# Altium schematic sheet FeedbackLoop.SchDoc
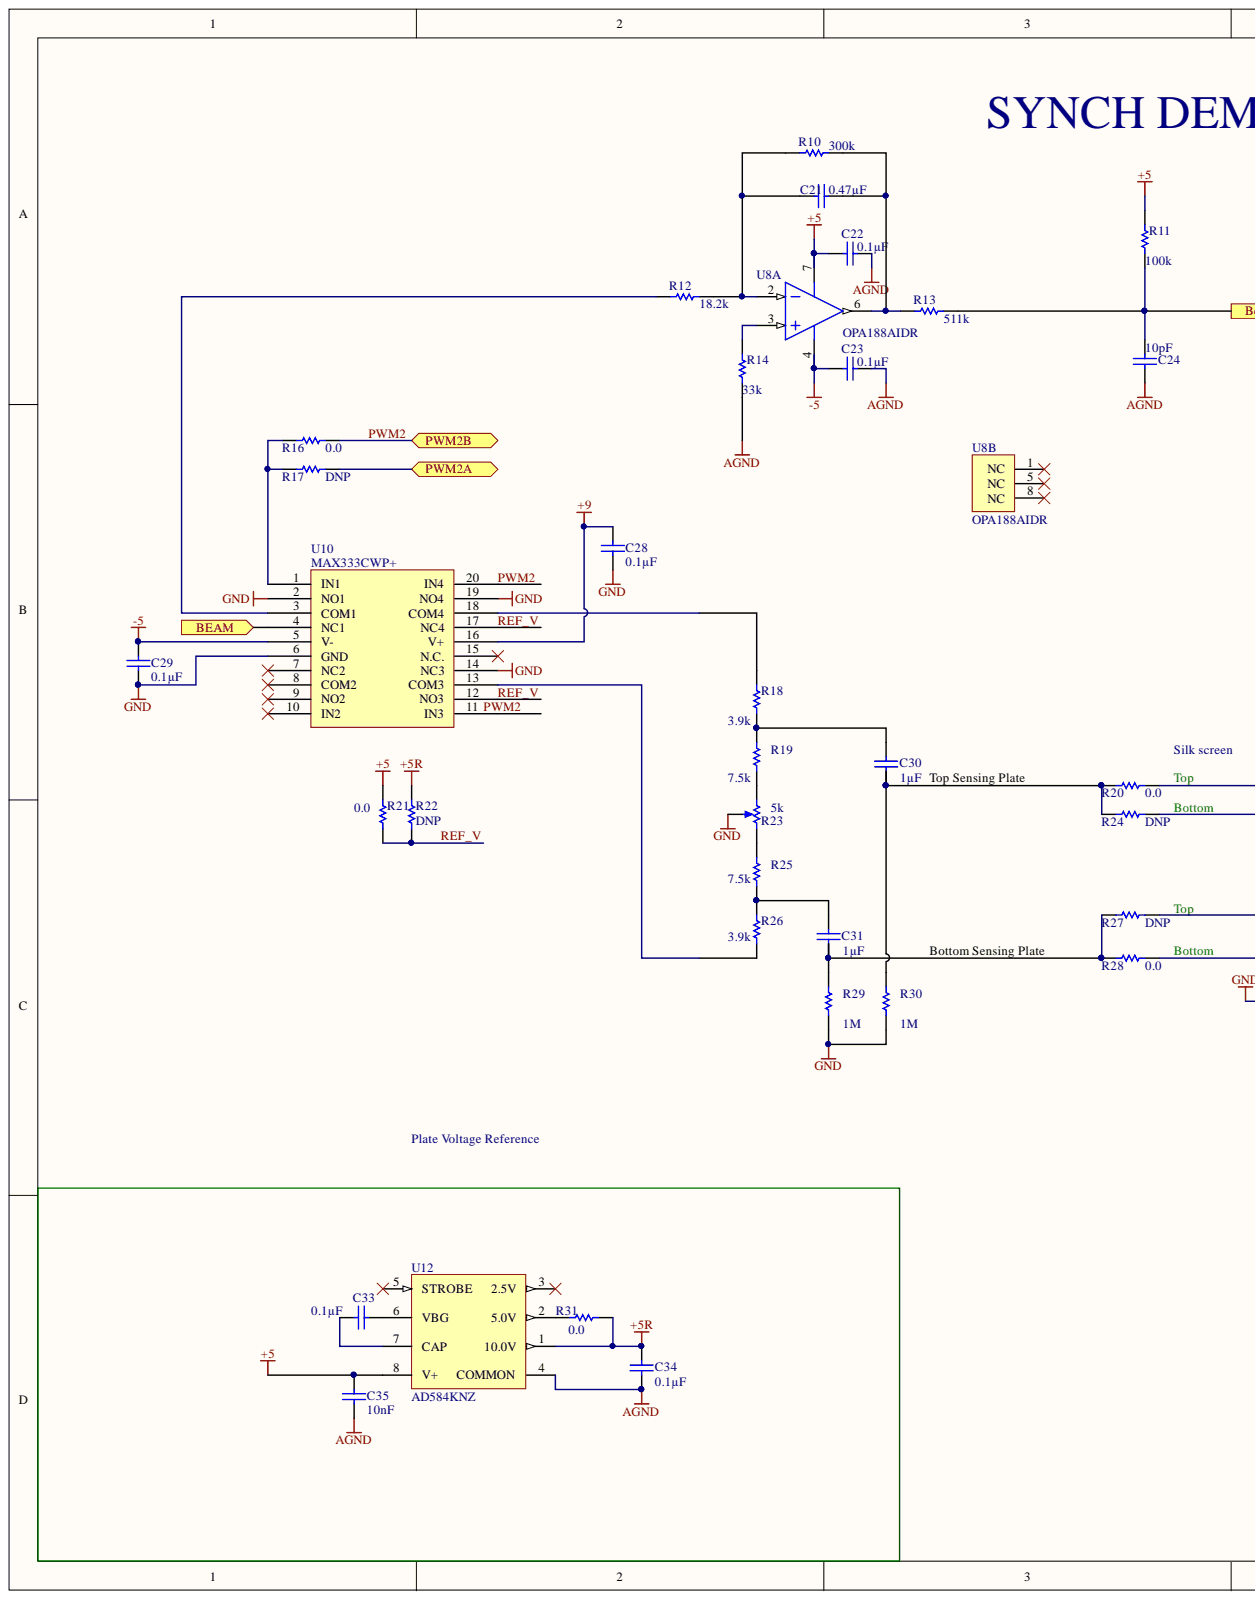
[diagram p0: FeedbackLoop.SchDoc, left]
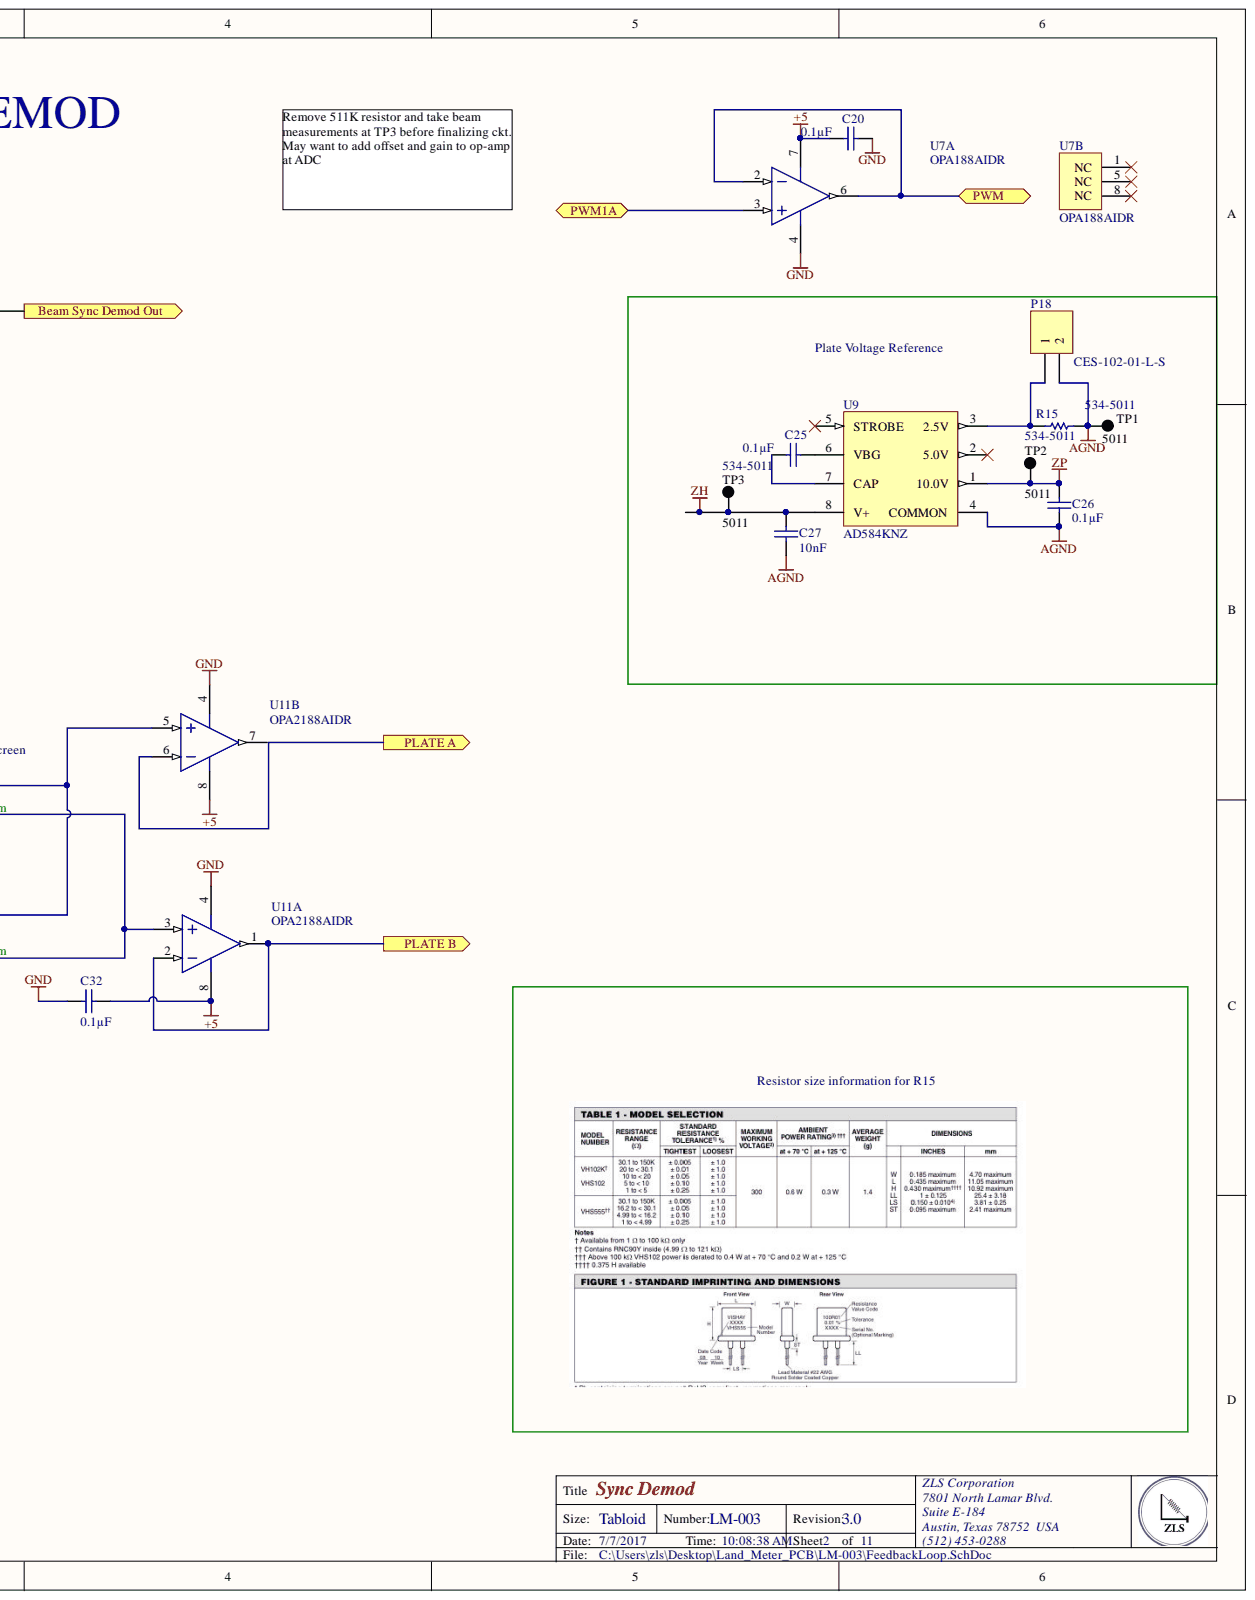
[diagram p1: FeedbackLoop.SchDoc, right]

## Parts
C20  Capacitance: 0.1µF  [CAPC0805(2012)100_N]  (hidden comment: CAP 100nF 25V 0805(2012))
C21  Capacitance: 0.47µF  [CAPC0805(2012)145_N]  (hidden comment: CAP CER 0.47UF 25V X7R 0805)
C22  Capacitance: 0.1µF  [CAPC0805(2012)145_N]  (hidden comment: CAP CER 0.1UF 16V X7R 0805)
C23  Capacitance: 0.1µF  [CAPC0805(2012)145_N]  (hidden comment: CAP CER 0.1UF 25V X7R 0805)
C24  Capacitance: 10pF  [CAPC0805(2012)145_N]  (hidden comment: CAP CER 10PF 200V C0G/NP0 0805)
C25  Capacitance: 0.1µF  [CAPC0805(2012)100_N]  (hidden comment: CAP 100nF 25V 0805(2012))
C26  Capacitance: 0.1µF  [CAPC0805(2012)145_N]  (hidden comment: CAP CER 0.1UF 25V X7R 0805)
C27  Capacitance: 10nF  [CAPC0805(2012)145_N]  (hidden comment: Multilayer Ceramic Capacitors MLCC - SMD/SMT 0.01uF 50volts 10% X7R)
C28  Capacitance: 0.1µF  [CAPC0805(2012)145_N]  (hidden comment: CAP CER 0.1UF 25V X7R 0805)
C29  Capacitance: 0.1µF  [CAPC0805(2012)145_N]  (hidden comment: CAP CER 0.1UF 25V X7R 0805)
C30  Capacitance: 1µF  [CAPC0805(2012)155_L]  (hidden comment: CAP CER 1UF 25V X7R 0805)
C31  Capacitance: 1µF  [CAPC0805(2012)155_L]  (hidden comment: CAP CER 1UF 25V X7R 0805)
C32  Capacitance: 0.1µF  [CAPC0805(2012)155_L]  (hidden comment: CAP CER 0.1UF 25V X7R 0805)
C33  Capacitance: 0.1µF  [CAPC0805(2012)100_N]  (hidden comment: CAP 100nF 25V 0805(2012))
C34  Capacitance: 0.1µF  [CAPC0805(2012)145_N]  (hidden comment: CAP CER 0.1UF 25V X7R 0805)
C35  Capacitance: 10nF  [CAPC0805(2012)145_N]  (hidden comment: Multilayer Ceramic Capacitors MLCC - SMD/SMT 0.01uF 50volts 10% X7R)
P18  LOW PROFILE .025" SQUARE STRIPS  [CES-102-01-L-S]  (value not drawn)
R10  Resistance (Ohms): 300k  [RESC0805(2012)_M]  (hidden comment: RES SMD 300K OHM 1% 1/8W 0805)
R11  Resistance (Ohms): 100k  [RESC0805(2012)_M]  (hidden comment: RES SMD 100K OHM 1% 1/2W 0805)
R12  Resistance (Ohms): 18.2k  [RESC0805(2012)_M]  (hidden comment: RES SMD 18.2K OHM 1% 1/8W 0805)
R13  Resistance (Ohms): 511k  [RESC0805(2012)_M]  (hidden comment: RES SMD 511K OHM 1% 1/8W 0805)
R14  Resistance (Ohms): 33k  [RESC0805(2012)_M]  (hidden comment: RES SMD 33K OHM 1% 1/2W 0805)
R15  TBD  [VH102K]  (value not drawn)
R16  Resistance (Ohms): 0.0  [RESC0805(2012)_M]  (hidden comment: RES SMD 0.0 OHM JUMPER 1/8W 0805)
R17  Resistance (Ohms): DNP  [RESC0805(2012)_M]  (hidden comment: RES SMD 0.0 OHM JUMPER 1/8W 0805)
R18  Resistance (Ohms): 3.9k  [RESC0805(2012)_N]  (hidden comment: RES SMD 3.9K OHM 5% 1/8W 0805)
R19  Resistance (Ohms): 7.5k  [RESC0805(2012)_N]  (hidden comment: RES SMD 7.5K OHM 1% 1/8W 0805)
R20  Resistance (Ohms): 0.0  [RESC0805(2012)_M]  (hidden comment: RES SMD 0.0 OHM JUMPER 1/8W 0805)
R21  Resistance (Ohms): 0.0  [RESC0805(2012)_M]  (hidden comment: RES SMD 0.0 OHM JUMPER 1/8W 0805)
R22  DNP  [RESC0805(2012)_M]
R23  Resistance (Ohms): 5k  [Trimmer 2.54]  (hidden comment: TRIMMER 5K OHM 0.25W PC PIN)
R24  Resistance (Ohms): DNP  [RESC0805(2012)_M]  (hidden comment: RES SMD 0.0 OHM JUMPER 1/8W 0805)
R25  Resistance (Ohms): 7.5k  [RESC0805(2012)_N]  (hidden comment: RES SMD 7.5K OHM 1% 1/8W 0805)
R26  Resistance (Ohms): 3.9k  [RESC0805(2012)_N]  (hidden comment: RES SMD 3.9K OHM 5% 1/8W 0805)
R27  Resistance (Ohms): DNP  [RESC0805(2012)_M]  (hidden comment: RES SMD 0.0 OHM JUMPER 1/8W 0805)
R28  Resistance (Ohms): 0.0  [RESC0805(2012)_M]  (hidden comment: RES SMD 0.0 OHM JUMPER 1/8W 0805)
R29  Resistance (Ohms): 1M  [RESC0805(2012)_N]  (hidden comment: RES SMD 1M OHM 1% 1/8W 0805)
R30  Resistance (Ohms): 1M  [RESC0805(2012)_N]  (hidden comment: RES SMD 1M OHM 1% 1/8W 0805)
R31  Resistance (Ohms): 0.0  [RESC0805(2012)_M]  (hidden comment: RES SMD 0.0 OHM JUMPER 1/8W 0805)
TP1  5011  Supplier Part Number 1: 534-5011  [KSTN-5011_V]
TP2  5011  Supplier Part Number 1: 534-5011  [KSTN-5011_V]
TP3  5011  Supplier Part Number 1: 534-5011  [KSTN-5011_V]
U7  OPA188AIDR  [D0008A_M]  (parts A,B)
U8  OPA188AIDR  [D0008A_M]  (parts A,B)
U9  AD584KNZ  [ADI-N-8]
U10  MAX333CWP+  [TSOP65P640X90-20N]
U11  OPA2188AIDR  [D0008A_L]  (parts A,B)
U12  AD584KNZ  [ADI-N-8]

## Ports
BEAM, Beam Sync Demod Out, PLATE A, PLATE B, PWM, PWM1A, PWM2A, PWM2B

## Nets
+5: C20.1 C22.1 C32.1 C35.1 R11.2 R21.2 U7.7 U8.7 U11.8 U12.8(V+)
+5R: C34.1 R22.2 R31.1 U12.1(10.0V)
+9: C28.2 U10.16(V+)
-5: C23.2 C29.1 U8.4 U10.5(V-)
AGND: C22.2 C23.1 C24.2 C26.2 C27.2 C34.2 C35.2 P18.2 R14.1 R15.2 TP1.1 U9.4(COMMON) U12.4(COMMON)
GND: C20.2 C28.1 C29.2 C32.2 R23.2 R29.1 R30.1 U7.4 U10.2(NO1) U10.6(GND) U10.14(NC3) U10.19(NO4) U11.4
ZH: C27.1 TP3.1 U9.8(V+)
ZP: C26.1 TP2.1 U9.1(10.0V)
BEAM: U10.4(NC1) ; port BEAM
Beam Sync Demod Out: C24.1 R11.1 R13.1 ; port Beam Sync Demod Out
PLATE A: U11.6 ; port PLATE A
PLATE B: U11.1 U11.2 ; port PLATE B
PWM: U7.2 U7.6 ; port PWM
PWM1A: U7.3 ; port PWM1A
PWM2: R16.1 U10.20(IN4) ; port PWM2B
PWM2A: R17.1 ; port PWM2A
REF_V: R21.1 R22.1 U10.12(NO3) U10.17(NC4)
NetC21_1: C21.1 R10.2 R12.2 U8.2
NetC21_2: C21.2 R10.1 R13.2 U8.6
NetC25_1: C25.1 U9.7(CAP)
NetC25_2: C25.2 U9.6(VBG)
NetC30_1: C30.1 R20.2 R24.2 R30.2
NetC30_2: C30.2 R18.1 R19.2
NetC31_1: C31.1 R27.2 R28.2 R29.2
NetC31_2: C31.2 R25.1 R26.2
NetC33_1: C33.1 U12.7(CAP)
NetC33_2: C33.2 U12.6(VBG)
NetP18_1: P18.1 R15.1 U9.3(2.5V)
NetR12_1: R12.1 U10.3(COM1)
NetR14_2: R14.2 U8.3
NetR16_2: R16.2 R17.2 U10.1(IN1)
NetR18_2: R18.2 U10.18(COM4)
NetR19_1: R19.1 R23.3
NetR20_1: R20.1 R27.1 U11.5
NetR23_1: R23.1 R25.2
NetR24_1: R24.1 R28.1 U11.3
NetR26_1: R26.1 U10.13(COM3)
NetR31_2: R31.2 U12.2(5.0V)

Not connected: U7 1(NC) 5(NC) 8(NC); U8 1(NC) 5(NC) 8(NC); U9 2(5.0V) 5(STROBE); U10 7(NC2) 8(COM2) 9(NO2) 10(IN2) 11(IN3) 15(N.C.); U11 7; U12 3(2.5V) 5(STROBE)

## Library data of the parts
C20 C25 C33 = CMP-1036-04416-1: CAP 100nF 25V -20% to +80% 0805 (2012 Metric) Thickness 1mm SMD; Manufacturer: Murata Electronics North America; Manufacturer Part Number: GRM21BR71E104KA01L; Temperature Coefficient: X7R; Tolerance: ±10%; Applications: General Purpose; Category: Capacitors; Component Kind: Standard; ComponentLink1Description: http://www.murata.com/~/media/webrenewal/support/library/catalog/products/capacitor/mlcc/c02e.ashx; ComponentLink1URL: http://www.murata.com/~/media/webrenewal/support/library/catalog/products/capacitor/mlcc/c02e.ashx; Features: -; Height - Seated (Max): -; Lead Spacing: -; Lead Style: -; Library Name: Altium Content Vault; Mounting Type: Surface Mount, MLCC; Operating Temperature: -55°C ~ 125°C; Package / Case: 0805 (2012 Metric); Packaging: Cut Tape (CT); Part Status: Active; Pricing 1: 1=0.2, 10=0.145, 100=0.0683, 500=0.04788, 1000=0.03964 (USD); Ratings: -; RoHS: RoHS Compliant; Size / Dimension: 0.079" L x 0.049" W (2.00mm x 1.25mm); Stock 1: 895391; Supplier 1: Digi-Key; Supplier Part Number 1: 490-1673-1-ND; Thickness (Max): 0.053" (1.35mm); Voltage - Rated: 25V
C21 = CMP-1036-04795-1: Manufacturer: Murata Electronics North America; Manufacturer Part Number: GRM219R71E474KA88D; Tolerance: ±10%; Applications: General Purpose; Category: Capacitors; Component Kind: Standard; ComponentLink1Description: http://www.murata.com/~/media/webrenewal/support/library/catalog/products/capacitor/mlcc/c02e.ashx; ComponentLink1URL: http://www.murata.com/~/media/webrenewal/support/library/catalog/products/capacitor/mlcc/c02e.ashx; Description: CAP CER 0.47UF 25V X7R 0805; Features: -; Height - Seated (Max): -; Lead Spacing: -; Lead Style: -; Library Name: Altium Content Vault; Mounting Type: Surface Mount, MLCC; Operating Temperature: -55°C ~ 125°C; Package / Case: 0805 (2012 Metric); Packaging: Digi-Reel®; Part Status: Active; Pricing 1: 1=0.33, 10=0.229, 100=0.1377, 500=0.10324, 1000=0.08718 (USD); Ratings: -; RoHS: RoHS Compliant; Size / Dimension: 0.079" L x 0.049" W (2.00mm x 1.25mm); Stock 1: 575; Supplier 1: Digi-Key; Supplier Part Number 1: 490-11584-6-ND; Temperature Coefficient: X7R; Thickness (Max): 0.037" (0.95mm); Voltage - Rated: 25V
C22 = CMP-1036-04795-1: Manufacturer: Murata Electronics North America; Manufacturer Part Number: GRM219R71C104KA01D; Tolerance: ±10%; Voltage - Rated: 25V; Applications: General Purpose; Category: Capacitors; Component Kind: Standard; ComponentLink1Description: http://www.murata.com/~/media/webrenewal/support/library/catalog/products/capacitor/mlcc/c02e.ashx; ComponentLink1URL: http://www.murata.com/~/media/webrenewal/support/library/catalog/products/capacitor/mlcc/c02e.ashx; Description: CAP CER 0.1UF 16V X7R 0805; Features: -; Height - Seated (Max): -; Lead Spacing: -; Lead Style: -; Library Name: Altium Content Vault; Mounting Type: Surface Mount, MLCC; Operating Temperature: -55°C ~ 125°C; Package / Case: 0805 (2012 Metric); Packaging: Digi-Reel®; Part Status: Active; Pricing 2: 1=0.14, 10=0.098, 100=0.0462, 500=0.0324, 1000=0.02683 (USD); Ratings: -; RoHS: RoHS Compliant; Size / Dimension: 0.079" L x 0.049" W (2.00mm x 1.25mm); Stock 2: 245331; Supplier 2: Digi-Key; Supplier Part Number 1: 490-1673-6-ND; Supplier Part Number 2: 490-1683-6-ND; Temperature Coefficient: X7R; Thickness (Max): 0.053" (1.35mm)
C23 C26 C28 C29 C34 = CMP-1036-04795-1: Manufacturer: Murata Electronics North America; Manufacturer Part Number: GRM21BR71E104KA01L; Tolerance: ±10%; Applications: General Purpose; Category: Capacitors; Component Kind: Standard; ComponentLink1Description: http://www.murata.com/~/media/webrenewal/support/library/catalog/products/capacitor/mlcc/c02e.ashx; ComponentLink1URL: http://www.murata.com/~/media/webrenewal/support/library/catalog/products/capacitor/mlcc/c02e.ashx; Description: CAP CER 0.1UF 25V X7R 0805; Features: -; Height - Seated (Max): -; Lead Spacing: -; Lead Style: -; Library Name: Altium Content Vault; Mounting Type: Surface Mount, MLCC; Operating Temperature: -55°C ~ 125°C; Package / Case: 0805 (2012 Metric); Packaging: Digi-Reel®; Part Status: Active; Pricing 1: 1=0.2, 10=0.145, 100=0.0683, 500=0.04788, 1000=0.03964 (USD); Ratings: -; RoHS: RoHS Compliant; Size / Dimension: 0.079" L x 0.049" W (2.00mm x 1.25mm); Stock 1: 891652; Supplier 1: Digi-Key; Supplier Part Number 1: 490-1673-6-ND; Temperature Coefficient: X7R; Thickness (Max): 0.053" (1.35mm); Voltage - Rated: 25V
C24 = CMP-1036-04795-1: Manufacturer: Murata Electronics North America; Manufacturer Part Number: GRM21A5C2D100JW01D; Temperature Coefficient: C0G, NP0; Tolerance: ±5%; Applications: General Purpose; Category: Capacitors; Component Kind: Standard; ComponentLink1Description: http://search.murata.co.jp/Ceramy/image/img/A01X/G101/ENG/GRM21A5C2D100JW01-01.pdf; ComponentLink1URL: http://search.murata.co.jp/Ceramy/image/img/A01X/G101/ENG/GRM21A5C2D100JW01-01.pdf; Description: CAP CER 10PF 200V C0G/NP0 0805; Failure Rate: -; Features: -; Height - Seated (Max): -; Lead Spacing: -; Lead Style: -; Library Name: Altium Content Vault; Mounting Type: Surface Mount, MLCC; Operating Temperature: -55°C ~ 125°C; Package / Case: 0805 (2012 Metric); Packaging: Cut Tape (CT); Part Status: Active; Pricing 1: 1=0.3, 10=0.205, 100=0.1038, 500=0.07104, 1000=0.06285 (USD); Ratings: -; RoHS: RoHS Compliant; Size / Dimension: 0.079" L x 0.049" W (2.00mm x 1.25mm); Stock 1: 957; Supplier 1: Digi-Key; Supplier Part Number 1: 490-14649-1-ND; Thickness (Max): 0.039" (1.00mm); Voltage - Rated: 200V
C27 C35 = CMP-1036-04795-1: Manufacturer: Kemet; Manufacturer Part Number: C0805C103K5RACAUTO; Voltage Rating: 50V; Case/Package: 0805; Case Code (Imperial): 0805; Case Code (Metric): 2012; Component Kind: Standard; ComponentLink1Description: https://datasheet.ciiva.com/22700/1693454-22700519.pdf; ComponentLink1URL: https://datasheet.ciiva.com/22700/1693454-22700519.pdf; ComponentLink2Description: https://datasheet.ciiva.com/7842/1693454-7842175.pdf; ComponentLink2URL: https://datasheet.ciiva.com/7842/1693454-7842175.pdf; Contact Plating: Tin; Depth: 1.25mm; Description: Multilayer Ceramic Capacitors MLCC - SMD/SMT 0.01uF 50volts 10% X7R; Dielectric: X7R; Dielectric Material: Ceramic; Height: 0.78mm; Length: 2mm; Library Name: Altium Content Vault; Max Operating Temperature: 125°C; Min Operating Temperature: -55°C; Mount: Surface Mount; Package Quantity: 1; Packaging: Tape and Reel; Pricing 1: 1=0.12, 10=0.087, 100=0.041, 500=0.0287, 1000=0.0238 (USD); Radiation Hardening: No; REACH SVHC: No SVHC; Stock 1: 63236; Supplier 1: Digi-Key; Supplier Part Number 1: 399-6924-1-ND; Termination: SMD/SMT; Thickness: 0.035inch; Tolerance: 10%; Voltage Rating (DC): 50V; Width: 0.049inch
C30 C31 = CMP-1036-04882-1: Manufacturer: Murata Electronics North America; Manufacturer Part Number: GRM21BR71E105KA99L; Tolerance: ±10%; Applications: General Purpose; Category: Capacitors; Component Kind: Standard; ComponentLink1Description: http://www.murata.com/~/media/webrenewal/support/library/catalog/products/capacitor/mlcc/c02e.ashx; ComponentLink1URL: http://www.murata.com/~/media/webrenewal/support/library/catalog/products/capacitor/mlcc/c02e.ashx; Description: CAP CER 1UF 25V X7R 0805; Features: -; Height - Seated (Max): -; Lead Spacing: -; Lead Style: -; Library Name: Altium Content Vault; Mounting Type: Surface Mount, MLCC; Operating Temperature: -55°C ~ 125°C; Package / Case: 0805 (2012 Metric); Packaging: Digi-Reel®; Part Status: Active; Pricing 1: 1=0.14, 10=0.099, 100=0.0468, 500=0.0328, 1000=0.02715 (USD); Ratings: -; RoHS: RoHS Compliant; Size / Dimension: 0.079" L x 0.049" W (2.00mm x 1.25mm); Stock 1: 295074; Supplier 1: Digi-Key; Supplier Part Number 1: 490-6484-6-ND; Temperature Coefficient: X7R; Thickness (Max): 0.053" (1.35mm); Voltage - Rated: 25V
C32 = CMP-1036-04882-1: Manufacturer: Murata Electronics North America; Manufacturer Part Number: GRM21BR71E104KA01L; Tolerance: ±10%; Applications: General Purpose; Category: Capacitors; Component Kind: Standard; ComponentLink1Description: http://www.murata.com/~/media/webrenewal/support/library/catalog/products/capacitor/mlcc/c02e.ashx; ComponentLink1URL: http://www.murata.com/~/media/webrenewal/support/library/catalog/products/capacitor/mlcc/c02e.ashx; Description: CAP CER 0.1UF 25V X7R 0805; Features: -; Height - Seated (Max): -; Lead Spacing: -; Lead Style: -; Library Name: Altium Content Vault; Mounting Type: Surface Mount, MLCC; Operating Temperature: -55°C ~ 125°C; Package / Case: 0805 (2012 Metric); Packaging: Digi-Reel®; Part Status: Active; Pricing 1: 1=0.2, 10=0.145, 100=0.0683, 500=0.04788, 1000=0.03964 (USD); Ratings: -; RoHS: RoHS Compliant; Size / Dimension: 0.079" L x 0.049" W (2.00mm x 1.25mm); Stock 1: 891652; Supplier 1: Digi-Key; Supplier Part Number 1: 490-1673-6-ND; Temperature Coefficient: X7R; Thickness (Max): 0.053" (1.35mm); Voltage - Rated: 25V
P18 = Header 2: Manufacturer: Samtec Inc.; Connector Type: Receptacle; Contact Length - Post: 0.128" (3.25mm); Material Flammability Rating: UL94 V-0; Number of Positions: 2; Number of Positions Loaded: All; Pitch - Mating: 0.100" (2.54mm); Style: Board to Board; Applications: -; Category: Connectors, Interconnects; Component Kind: Standard; ComponentLink1Description: http://suddendocs.samtec.com/prints/ces-1xx-xx-x-s-xx-mkt.pdf; ComponentLink1URL: http://suddendocs.samtec.com/prints/ces-1xx-xx-x-s-xx-mkt.pdf; Contact Finish - Mating: Gold; Contact Finish - Post: Tin; Contact Finish Thickness - Mating: 10µin (0.25µm); Contact Finish Thickness - Post: -; Contact Material: Phosphor Bronze; Contact Shape: Square; Contact Type: Female Socket; Current Rating: -; Description: LOW PROFILE .025" SQUARE STRIPS; Fastening Type: Push-Pull; Features: -; Ingress Protection: -; Insulation Color: Black; Insulation Height: 0.200" (5.08mm); Insulation Material: Polybutylene Terephthalate (PBT); Library Name: Miscellaneous Connectors.IntLib; Manufacturer Part Number: CES-102-01-L-S; Mated Stacking Heights: -; Mounting Type: Through Hole; Number of Rows: 1; Operating Temperature: -55°C ~ 125°C; Packaging: Bulk; Part Status: Active; Pricing 1: 1=0.61 (USD); RoHS: RoHS Compliant; Row Spacing - Mating: -; Signal Integrity: Connector; Stock 1: 0; Supplier 1: Digi-Key; Supplier Part Number 1: CES-102-01-L-S-ND; Termination: Solder; Voltage Rating: -
R10 = CMP-1013-00017-1: Manufacturer: Vishay Dale; Manufacturer Part Number: CRCW0805300KFKEA; Power (Watts): 0.125W, 1/8W; Temperature Coefficient: ±100ppm/°C; Category: Resistors; Component Kind: Standard; ComponentLink1Description: http://www.vishay.com/docs/20035/dcrcwe3.pdf; ComponentLink1URL: http://www.vishay.com/docs/20035/dcrcwe3.pdf; Composition: Thick Film; Description: RES SMD 300K OHM 1% 1/8W 0805; Failure Rate: -; Features: Automotive AEC-Q200; Height - Seated (Max): 0.020" (0.50mm); Library Name: Altium Content Vault; Operating Temperature: -55°C ~ 155°C; Package / Case: 0805 (2012 Metric); Packaging: Digi-Reel®; Part Status: Active; Pricing 1: 1=0.1, 10=0.044, 100=0.0178, 1000=0.00802, 2500=0.00696 (USD); RoHS: RoHS Compliant; Size / Dimension: 0.079" L x 0.049" W (2.00mm x 1.25mm); Stock 1: 22918; Supplier 1: Digi-Key; Supplier Device Package: 0805; Supplier Part Number 1: 541-300KCDKR-ND; Tolerance: ±1%
R11 = CMP-1013-00017-1: Manufacturer: Vishay Dale; Manufacturer Part Number: CRCW0805100KFKEAHP; Power (Watts): 0.5W, 1/2W; Temperature Coefficient: ±100ppm/°C; Category: Resistors; Component Kind: Standard; ComponentLink1Description: http://www.vishay.com/docs/20043/crcwhpe3.pdf; ComponentLink1URL: http://www.vishay.com/docs/20043/crcwhpe3.pdf; Composition: Thick Film; Description: RES SMD 100K OHM 1% 1/2W 0805; Failure Rate: -; Features: Automotive AEC-Q200, Pulse Withstanding; Height - Seated (Max): 0.020" (0.50mm); Library Name: Altium Content Vault; Operating Temperature: -55°C ~ 155°C; Package / Case: 0805 (2012 Metric); Packaging: Digi-Reel®; Part Status: Active; Pricing 1: 1=0.28, 10=0.233, 100=0.0911, 1000=0.03812, 2500=0.03494 (USD); RoHS: RoHS Compliant; Size / Dimension: 0.079" L x 0.049" W (2.00mm x 1.25mm); Stock 1: 1548; Supplier 1: Digi-Key; Supplier Device Package: 0805; Supplier Part Number 1: 541-100KTDKR-ND; Tolerance: ±1%
R12 = CMP-1013-00017-1: Manufacturer: Vishay Dale; Manufacturer Part Number: CRCW080518K2FKEA; Power (Watts): 0.125W, 1/8W; Temperature Coefficient: ±100ppm/°C; Category: Resistors; Component Kind: Standard; ComponentLink1Description: http://www.vishay.com/docs/20035/dcrcwe3.pdf; ComponentLink1URL: http://www.vishay.com/docs/20035/dcrcwe3.pdf; Composition: Thick Film; Description: RES SMD 18.2K OHM 1% 1/8W 0805; Failure Rate: -; Features: Automotive AEC-Q200; Height - Seated (Max): 0.020" (0.50mm); Library Name: Altium Content Vault; Operating Temperature: -55°C ~ 155°C; Package / Case: 0805 (2012 Metric); Packaging: Digi-Reel®; Part Status: Active; Pricing 1: 1=0.1, 10=0.044, 100=0.0178, 1000=0.00802, 2500=0.00696 (USD); RoHS: RoHS Compliant; Size / Dimension: 0.079" L x 0.049" W (2.00mm x 1.25mm); Stock 1: 12440; Supplier 1: Digi-Key; Supplier Device Package: 0805; Supplier Part Number 1: 541-18.2KCDKR-ND; Tolerance: ±1%
R13 = CMP-1013-00017-1: Manufacturer: Vishay Dale; Manufacturer Part Number: CRCW0805511KFKEA; Power (Watts): 0.125W, 1/8W; Temperature Coefficient: ±100ppm/°C; Category: Resistors; Component Kind: Standard; ComponentLink1Description: http://www.vishay.com/docs/20035/dcrcwe3.pdf; ComponentLink1URL: http://www.vishay.com/docs/20035/dcrcwe3.pdf; Composition: Thick Film; Description: RES SMD 511K OHM 1% 1/8W 0805; Failure Rate: -; Features: Automotive AEC-Q200; Height - Seated (Max): 0.020" (0.50mm); Library Name: Altium Content Vault; Operating Temperature: -55°C ~ 155°C; Package / Case: 0805 (2012 Metric); Packaging: Digi-Reel®; Part Status: Active; Pricing 1: 1=0.1, 10=0.044, 100=0.0178, 1000=0.00802, 2500=0.00696 (USD); RoHS: RoHS Compliant; Size / Dimension: 0.079" L x 0.049" W (2.00mm x 1.25mm); Stock 1: 39; Supplier 1: Digi-Key; Supplier Device Package: 0805; Supplier Part Number 1: 541-511KCDKR-ND; Tolerance: ±1%
R14 = CMP-1013-00017-1: Manufacturer: Vishay Dale; Manufacturer Part Number: CRCW080533K0FKEAHP; Power (Watts): 0.5W, 1/2W; Temperature Coefficient: ±100ppm/°C; Category: Resistors; Component Kind: Standard; ComponentLink1Description: http://www.vishay.com/docs/20043/crcwhpe3.pdf; ComponentLink1URL: http://www.vishay.com/docs/20043/crcwhpe3.pdf; Composition: Thick Film; Description: RES SMD 33K OHM 1% 1/2W 0805; Failure Rate: -; Features: Automotive AEC-Q200, Pulse Withstanding; Height - Seated (Max): 0.020" (0.50mm); Library Name: Altium Content Vault; Operating Temperature: -55°C ~ 155°C; Package / Case: 0805 (2012 Metric); Packaging: Digi-Reel®; Part Status: Active; Pricing 1: 1=0.28, 10=0.233, 100=0.0911, 1000=0.03812, 2500=0.03494 (USD); RoHS: RoHS Compliant; Size / Dimension: 0.079" L x 0.049" W (2.00mm x 1.25mm); Stock 1: 4835; Supplier 1: Digi-Key; Supplier Device Package: 0805; Supplier Part Number 1: 541-33.0KTDKR-ND; Tolerance: ±1%
R15 = CMP-1013-00393-1: Component Kind: Standard
R16 R17 R20 R21 R24 R27 R28 R31 = CMP-1013-00017-1: Manufacturer: Vishay Dale; Manufacturer Part Number: CRCW08050000Z0EA; Power (Watts): 0.125W, 1/8W; Category: Resistors; Component Kind: Standard; ComponentLink1Description: http://www.vishay.com/docs/20035/dcrcwe3.pdf; ComponentLink1URL: http://www.vishay.com/docs/20035/dcrcwe3.pdf; Composition: Thick Film; Description: RES SMD 0.0 OHM JUMPER 1/8W 0805; Failure Rate: -; Features: Automotive AEC-Q200; Height - Seated (Max): 0.020" (0.50mm); Library Name: Altium Content Vault; Operating Temperature: -55°C ~ 155°C; Package / Case: 0805 (2012 Metric); Packaging: Digi-Reel®; Part Status: Active; Pricing 1: 1=0.1, 10=0.026, 25=0.0184, 50=0.014, 100=0.0104, 250=0.00792, 500=0.00634, 1000=0.00466 (USD); RoHS: RoHS Compliant; Size / Dimension: 0.079" L x 0.049" W (2.00mm x 1.25mm); Stock 1: 407603; Supplier 1: Digi-Key; Supplier Device Package: 0805; Supplier Part Number 1: 541-0.0ADKR-ND; Temperature Coefficient: -; Tolerance: Jumper
R18 R26 = CMP-1013-00606-1: Manufacturer: Vishay Dale; Manufacturer Part Number: CRCW08053K90JNEA; Power (Watts): 0.125W, 1/8W; Temperature Coefficient: ±200ppm/°C; Category: Resistors; Component Kind: Standard; ComponentLink1Description: http://www.vishay.com/docs/20035/dcrcwe3.pdf; ComponentLink1URL: http://www.vishay.com/docs/20035/dcrcwe3.pdf; Composition: Thick Film; Description: RES SMD 3.9K OHM 5% 1/8W 0805; Failure Rate: -; Features: Automotive AEC-Q200; Height - Seated (Max): 0.020" (0.50mm); Library Name: Altium Content Vault; Operating Temperature: -55°C ~ 155°C; Package / Case: 0805 (2012 Metric); Packaging: Digi-Reel®; Part Status: Active; Pricing 1: 1=0.1, 10=0.028, 100=0.0114, 1000=0.00513, 2500=0.00445 (USD); RoHS: RoHS Compliant; Size / Dimension: 0.079" L x 0.049" W (2.00mm x 1.25mm); Stock 1: 20963; Supplier 1: Digi-Key; Supplier Device Package: 0805; Supplier Part Number 1: 541-3.9KADKR-ND; Tolerance: ±5%
R19 R25 = CMP-1013-00606-1: Manufacturer: Vishay Dale; Manufacturer Part Number: CRCW08057K50FKEA; Power (Watts): 0.125W, 1/8W; Temperature Coefficient: ±100ppm/°C; Category: Resistors; Component Kind: Standard; ComponentLink1Description: http://www.vishay.com/docs/20035/dcrcwe3.pdf; ComponentLink1URL: http://www.vishay.com/docs/20035/dcrcwe3.pdf; Composition: Thick Film; Description: RES SMD 7.5K OHM 1% 1/8W 0805; Failure Rate: -; Features: Automotive AEC-Q200; Height - Seated (Max): 0.020" (0.50mm); Library Name: Altium Content Vault; Operating Temperature: -55°C ~ 155°C; Package / Case: 0805 (2012 Metric); Packaging: Digi-Reel®; Part Status: Active; Pricing 1: 1=0.1, 10=0.044, 100=0.0178, 1000=0.00802, 2500=0.00696 (USD); RoHS: RoHS Compliant; Size / Dimension: 0.079" L x 0.049" W (2.00mm x 1.25mm); Stock 1: 0; Supplier 1: Digi-Key; Supplier Device Package: 0805; Supplier Part Number 1: 541-7.50KCDKR-ND; Tolerance: ±1%
R22 = CMP-1013-00017-1: RES SMD 0.0 OHM JUMPER 1/8W 0805; Manufacturer: Vishay Dale; Manufacturer Part Number: CRCW08050000Z0EA; Power (Watts): 0.125W, 1/8W; Resistance (Ohms): 0.0; Category: Resistors; Component Kind: Standard; ComponentLink1Description: http://www.vishay.com/docs/20035/dcrcwe3.pdf; ComponentLink1URL: http://www.vishay.com/docs/20035/dcrcwe3.pdf; Composition: Thick Film; Failure Rate: -; Features: Automotive AEC-Q200; Height - Seated (Max): 0.020" (0.50mm); Library Name: Altium Content Vault; Operating Temperature: -55°C ~ 155°C; Package / Case: 0805 (2012 Metric); Packaging: Digi-Reel®; Part Status: Active; Pricing 1: 1=0.1, 10=0.026, 25=0.0184, 50=0.014, 100=0.0104, 250=0.00792, 500=0.00634, 1000=0.00466 (USD); RoHS: RoHS Compliant; Size / Dimension: 0.079" L x 0.049" W (2.00mm x 1.25mm); Stock 1: 407603; Supplier 1: Digi-Key; Supplier Device Package: 0805; Supplier Part Number 1: 541-0.0ADKR-ND; Temperature Coefficient: -; Tolerance: Jumper
R23 = Trimmer: Manufacturer: Vishay Foil Resistors (Division of Vishay Precision Group); Manufacturer Part Number: Y00535K00000J0L; Adjustment Type: Side Adjustment; Number of Turns: 21; Power (Watts): 0.25W, 1/4W; Resistive Material: Metal Foil; Temperature Coefficient: ±10ppm/°C; Tolerance: ±5%; Category: Potentiometers, Variable Resistors; Component Kind: Standard; ComponentLink1Description: http://www.vishaypg.com/docs/63053/1240.pdf; ComponentLink1URL: http://www.vishaypg.com/docs/63053/1240.pdf; Description: TRIMMER 5K OHM 0.25W PC PIN; Mounting Type: Through Hole; Packaging: Bulk; Part Status: Active; Pricing 1: 1=34.42, 10=32.265, 25=26.5292, 50=22.944, 100=22.227, 250=20.793, 500=19.2156 (USD); RoHS: RoHS Compliant; Size / Dimension: Rectangular - 0.250" x 0.175" Face x 0.250" L (6.35mm x 4.45mm x 6.35mm); Stock 1: 679; Supplier 1: Digi-Key; Supplier Part Number 1: 804-1020-ND; Termination Style: PC Pins; Library Name: ZLS_Schematic_lib.SchLib
R29 R30 = CMP-1013-00606-1: Manufacturer: Vishay Dale; Manufacturer Part Number: CRCW08051M00FKEA; Power (Watts): 0.125W, 1/8W; Temperature Coefficient: ±100ppm/°C; Category: Resistors; Component Kind: Standard; ComponentLink1Description: http://www.vishay.com/docs/20035/dcrcwe3.pdf; ComponentLink1URL: http://www.vishay.com/docs/20035/dcrcwe3.pdf; Composition: Thick Film; Description: RES SMD 1M OHM 1% 1/8W 0805; Failure Rate: -; Features: Automotive AEC-Q200; Height - Seated (Max): 0.020" (0.50mm); Library Name: Altium Content Vault; Operating Temperature: -55°C ~ 155°C; Package / Case: 0805 (2012 Metric); Packaging: Digi-Reel®; Part Status: Active; Pricing 1: 1=0.1, 10=0.044, 100=0.0178, 1000=0.00802, 2500=0.00696 (USD); RoHS: RoHS Compliant; Size / Dimension: 0.079" L x 0.049" W (2.00mm x 1.25mm); Stock 1: 44065; Supplier 1: Digi-Key; Supplier Device Package: 0805; Supplier Part Number 1: 541-1.00MCDKR-ND; Tolerance: ±1%
TP1-TP3 = CMP-1672-00003-4: Test Point, Black, Through Hole, RoHS, Bulk; Manufacturer: Keystone; Body Material: PBT; Gender: Female; Hole Diameter: 1.6mm; Inside Diameter: 1.78mm; Number of Ports: 1; Case/Package: 125; Colour: Black; Component Kind: Standard; ComponentLink1Description: Manufacturer URL; ComponentLink1URL: http://www.keyelco.com/; ComponentLink2Description: Datasheet; ComponentLink2URL: http://www.keyelco.com/product-pdf.cfm?p=1320; ComponentLink3Description: https://datasheet.ciiva.com/1454/1718230-1454834.pdf; ComponentLink3URL: https://datasheet.ciiva.com/1454/1718230-1454834.pdf; ComponentLink4Description: https://datasheet.ciiva.com/2917/5011-2917635.pdf; ComponentLink4URL: https://datasheet.ciiva.com/2917/5011-2917635.pdf; ComponentLink5Description: https://datasheet.ciiva.com/16351/m60-2p60-16351093.pdf; ComponentLink5URL: https://datasheet.ciiva.com/16351/m60-2p60-16351093.pdf; ComponentLink6Description: https://datasheet.ciiva.com/11744/0900766b8009b7c7-11744935.pdf; ComponentLink6URL: https://datasheet.ciiva.com/11744/0900766b8009b7c7-11744935.pdf; ComponentLink7Description: https://datasheet.ciiva.com/46412/1703983-46412138.pdf; ComponentLink7URL: https://datasheet.ciiva.com/46412/1703983-46412138.pdf; Contact Material: Bronze; Contact Plating: Silver; DatasheetVersion: Rev. F, 12/2013; Height: 8.12 mm; Housing Colour: Black; Lead Free: Lead Free; Length: 11.3mm; Library Name: Altium Content Vault; Manufacturer Part Number: 5011; Material: Bronze,Silver,Nylon; Mount: Through Hole; Mounting Technology: Through Hole; Orientation: Straight; PackageDescription: 1-Pin Through Hole Device, Dia 3.17 mm; Package Quantity: 100; PackageReference: 5011; Packaging: Bulk; Pins: 1; Pricing 1: 1=0.53, 10=0.53, 50=0.53, 100=0.371, 1000=0.165, 10000=0.106 (USD); REACH SVHC: No SVHC; RoHSCompliant: true; Stock 1: 63090; Supplier 1: Mouser
U7 U8 = CMP-0809-00004-2: Precision, Low Noise, Rail-to-Rail Output, Zero-Drift Operational Amplifier, 4 to 36 V, -40 to 125 degC, 8-pin SOIC (D8), Green (RoHS & no Sb/Br); Manufacturer: Texas Instruments; CMRR(Min)(dB): 120; GBW(Typ)(MHz): 2; Iq per channel(Max)(mA): 0.51; Number of Channels: 1; Offset Drift(Typ)(uV/C): 0.03; Packing: LARGE T&R; Rating: Catalog; Slew Rate(Typ)(V/us): 0.8; SubFamily: Zero Drift Precision Amplifier; Total Supply Voltage(Max)(+5V is 5, +/-5V is 10): 36; Total Supply Voltage(Min)(+5V is 5, +/-5V is 10): 4; Code_JEDEC: MS-012; Component Kind: Standard; ComponentLink1Description: Manufacturer URL; ComponentLink1URL: http://www.ti.com/; ComponentLink2Description: Datasheet; ComponentLink2URL: http://www.ti.com/lit/ds/symlink/opa188.pdf; ComponentLink3Description: Package Specification; ComponentLink3URL: http://www.ti.com/litv/pdf/msoi002j; DatasheetVersion: SBOS642A; Eco-Plan: Green (RoHS & no Sb/Br); Library Name: Altium Content Vault; Mounting Technology: Surface Mount; PackageDescription: 8-Pin Plastic Small Outline, Body 4.9 x 3.9 mm, Pitch 1.27 mm; PackageReference: D0008A; PackageVersion: 4040047-3/M, 06/2011; PartId: 1; PartNumber: OPA188AIDR
U9 U12 = CMP-0784-00054-1: Pin Programmable, Precision Voltage Reference, + 2.5 / + 5 / + 7.5 / + 10 Prog V Output, 4.5 to 30 V Supply, Commercial, 8-pin DIP (N-8), Tube; Manufacturer: Analog Devices; Max V Supply (V): 30; Min V Supply (V): 4.5; Ref Out TC (ppm/C): 15; Vout: 2.5V, 5V, 7.5V, 10V; Code_JEDEC: MS-001; Component Kind: Standard; ComponentLink1Description: Manufacturer URL; ComponentLink1URL: http://www.analog.com/en/index.html; ComponentLink2Description: Datasheet; ComponentLink2URL: http://www.analog.com/static/imported-files/data_sheets/AD584.pdf; ComponentLink3Description: Package Specification; ComponentLink3URL: http:/www.analog.com/static/imported-files/packages/PKG_PDF/PDIP(N)/N_8.pdf; ComponentLink4Description: URL Link1; ComponentLink4URL: Product URL:http://www.analog.com/en/special-linear-functions/voltage-references/ad584/products/product.html; DatasheetVersion: Rev. C; Library Name: Altium Content Vault; Mounting Technology: Through Hole; Operating Temp Range: 0 to 70 degC; PackageDescription: 8-Pin Plastic Dual In-Line Package, Row spacing 7.62 mm, Pitch 2.54 mm; PackageReference: N-8; PackageVersion: 070606-A, 07/2006; Packing: Tube; PartNumber: AD584KNZ
U10 = MAX333CWP+: IC SWITCH QUAD SPDT 20TSSOP; Manufacturer: Maxim; Manufacturer Part Number: MAX333AEUP+; Dual Supply Voltage: 15V; Max Dual Supply Voltage: 20V; Max Power Dissipation: 559mW; Max Supply Current: 250uA; Max Supply Voltage: 30V; Min Dual Supply Voltage: 4.5V; Min Supply Voltage: 10V; Number of Bits: 4; On-State Resistance: 35R; Operating Supply Current: 1uA; Supply Type: Single,Dual; Turn-Off Delay Time: 145ns; Turn-On Delay Time: 175ns; Case/Package: TSSOP; Component Kind: Standard; ComponentLink1Description: https://datasheet.ciiva.com/2978/max333a-2978850.pdf; ComponentLink1URL: https://datasheet.ciiva.com/2978/max333a-2978850.pdf; ComponentLink2Description: https://datasheet.ciiva.com/30478/0900766b81253c85-30478260.pdf; ComponentLink2URL: https://datasheet.ciiva.com/30478/0900766b81253c85-30478260.pdf; ComponentLink3Description: https://datasheet.ciiva.com/1674/max333a-1674617.pdf; ComponentLink3URL: https://datasheet.ciiva.com/1674/max333a-1674617.pdf; ComponentLink4Description: https://datasheet.ciiva.com/26825/max333aeup-26825546.pdf; ComponentLink4URL: https://datasheet.ciiva.com/26825/max333aeup-26825546.pdf; Height: 0.95mm; Lead Free: Lead Free; Length: 6.6mm; Max Operating Temperature: 85°C; Min Operating Temperature: -40°C; Number of Channels: 4; Number of Pins: 20; Package Quantity: 74; Packaging: Rail/Tube; Pricing 1: 1=6.77, 10=6.43, 50=5.8, 100=5.8, 1000=5.62, 10000=5.62 (USD); Radiation Hardening: No; REACH SVHC: Unknown; Stock 1: 664; Supplier 1: Mouser; Supplier Part Number 1: 700-MAX333AEUP; Throw Configuration: SPDT; Weight: 0.006737oz; Width: 4.5mm; Library Name: ZLS_Schematic_lib.SchLib
U11 = CMP-0272-00241-3: 0.03 uV/degC, 6 uV Vos, Low Noise, Rail-to-Rail Output, Zero-Drift Operational Amplifier, 4 to 36 V, -40 to 105 degC, 8-pin SOIC (D8), Green (RoHS & no Sb/Br); Manufacturer: Texas Instruments; Current - Output / Channel: 18mA; Current - Supply: 415µA; Number of Circuits: 2; Slew Rate: 0.8 V/µs; Voltage - Supply, Single/Dual (±): 4 V ~ 36 V, ±2 V ~ 18 V; -3db Bandwidth: -; Amplifier Type: Zero-Drift; Category: Integrated Circuits (ICs); Component Kind: Standard; ComponentLink1Description: http://www.ti.com/lit/ds/symlink/opa2188.pdf; ComponentLink1URL: http://www.ti.com/lit/ds/symlink/opa2188.pdf; Library Name: Altium Content Vault; Manufacturer Part Number: OPA2188AIDR; Mounting Type: Surface Mount; Operating Temperature: -40°C ~ 105°C; Output Type: Rail-to-Rail; Package / Case: 8-SOIC (0.154", 3.90mm Width); Packaging: Digi-Reel®; Part Status: Active; Pricing 1: 1=3.44, 10=3.089, 100=2.5307, 500=2.15434, 1000=1.81692 (USD); RoHS: RoHS Compliant; Stock 1: 8272; Supplier 1: Digi-Key; Supplier Device Package: 8-SOIC; Supplier Part Number 1: 296-29454-6-ND
